# Altium schematic sheet Qbot2.SchDoc(Qbot2)
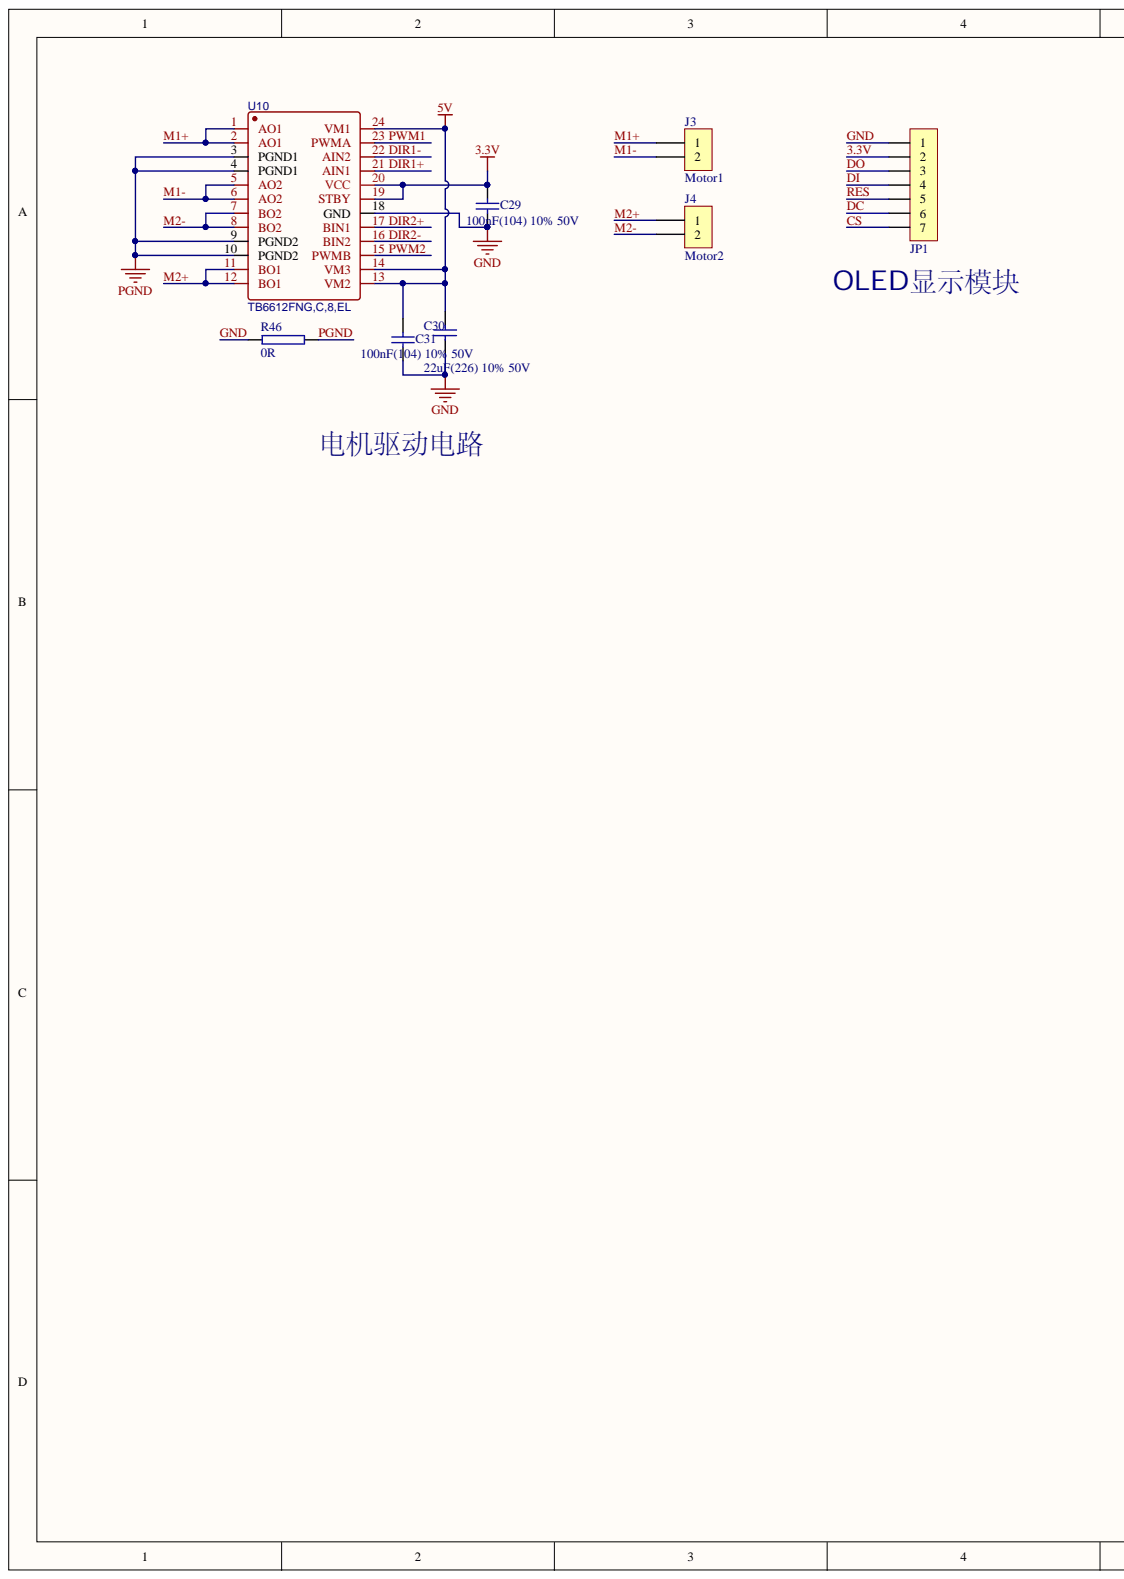
[diagram p0: Qbot2.SchDoc, left]
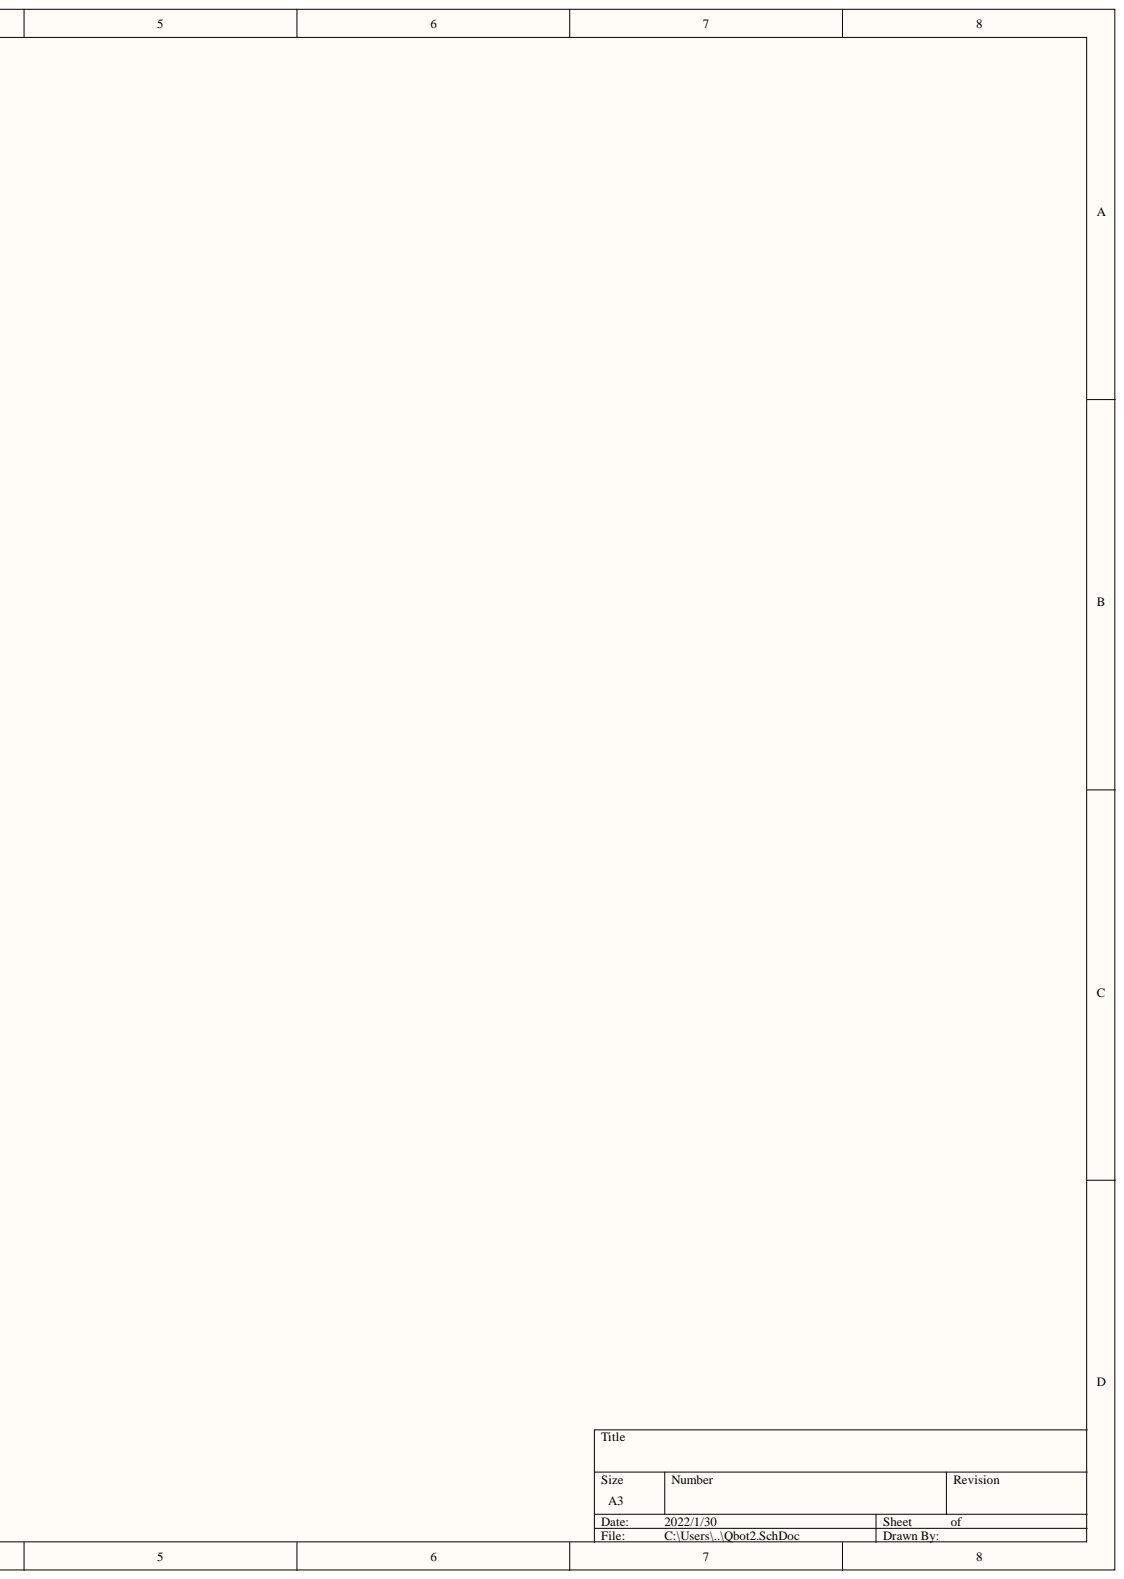
[diagram p1: Qbot2.SchDoc, right]

## Parts
C29  100nF(104) 10% 50V  [LC-0805_C]
C30  22uF(226) 10% 50V  [CD B(3528)]
C31  100nF(104) 10% 50V  [LC-0805_C]
J3  Motor1  [CONN-TH_1.25T-2A_1.25T-2A]
J4  Motor2  [CONN-TH_1.25T-2A_1.25T-2A]
JP1  HDR-1X7  [HDR2.54-M-LI-7P]  (value not drawn)
R46  0R  [LC-0805_R]
U10  TB6612FNG,C,8,EL  [SSOP24]

## Nets
5V: C30.2 C31.2 U10.13(VM2) U10.14(VM3) U10.24(VM1)
3.3V: C29.2 JP1.2 U10.19(STBY) U10.20(VCC)
CS: JP1.7
DC: JP1.6
DI: JP1.4
DIR1+: U10.21(AIN1)
DIR1-: U10.22(AIN2)
DIR2+: U10.17(BIN1)
DIR2-: U10.16(BIN2)
DO: JP1.3
GND: C29.1 C30.1 C31.1 JP1.1 R46.1 U10.18(GND)
M1+: J3.1 U10.1(AO1) U10.2(AO1)
M1-: J3.2 U10.5(AO2) U10.6(AO2)
M2+: J4.1 U10.11(BO1) U10.12(BO1)
M2-: J4.2 U10.7(BO2) U10.8(BO2)
PGND: R46.2 U10.3(PGND1) U10.4(PGND1) U10.9(PGND2) U10.10(PGND2)
PWM1: U10.23(PWMA)
PWM2: U10.15(PWMB)
RES: JP1.5

## Library data of the parts
C29-C31 = 0805 100nF(104) 10% 50V: 贴片电容; SuppliersPartNumber: C49678; Supplier: LC; Package: 0805; Library Name: Miscellaneous Devices LC.IntLib; ComponentLink1URL: http://www.szlcsc.com/product/details_50686.html; ComponentLink1Description: 供应商链接; Component Kind: Standard
J3 J4 = SIP2: jointbar; Component Kind: Standard; Library Name: 接口与插座.SCHLIB
JP1 = Header 7: 7P接插件; 版权归属: 源创客; 学习资源: www.ooocke.com; Library Name: 4.2   - 接插件-脚距1.25.SchLib; Component Kind: Standard; BOM名称: 7P接插件
R46 = RES: Component Kind: Standard
U10 = TB6612FNG,C,8,EL: BOM_Manufacturer: TOSHIBA; Contributor: LCSC; spiceSymbolName: TB6612FNG,C,8,EL; spicePre: U; Component Kind: Standard; BOM_Supplier Part: C141517; BOM_Supplier: LCSC; BOM_Manufacturer Part: TB6612FNG,C,8,EL; BOM_JLCPCB Part Class: Extended Part; Library Name: Qbot.SCHLIB
